# Altium schematic sheet 2 - 5V Buck.SchDoc
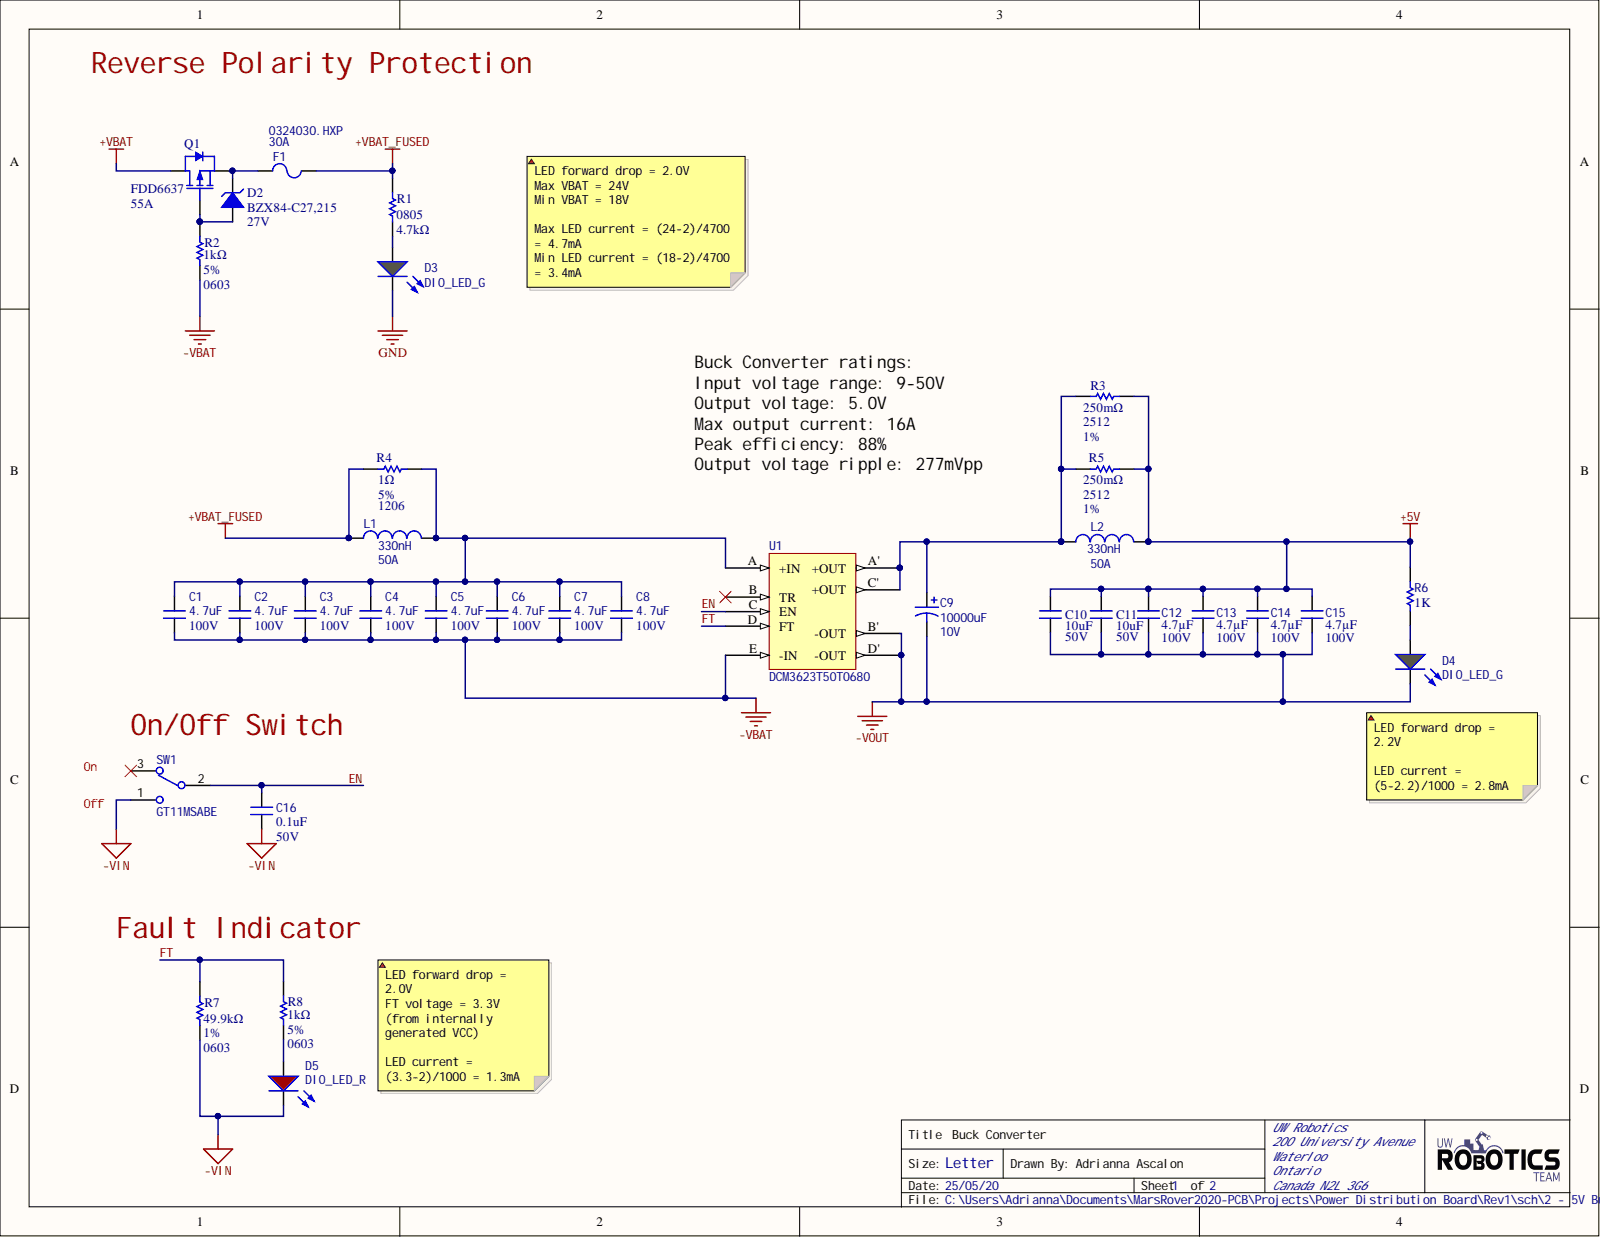
[diagram p0: 2 - 5V Buck.SchDoc]

## Parts
C1  4.7uF  Voltage: 100V  [CAPC5750X7R2A]
C2  4.7uF  Voltage: 100V  [CAPC5750X7R2A]
C3  4.7uF  Voltage: 100V  [CAPC5750X7R2A]
C4  4.7uF  Voltage: 100V  [CAPC5750X7R2A]
C5  4.7uF  Voltage: 100V  [CAPC5750X7R2A]
C6  4.7uF  Voltage: 100V  [CAPC5750X7R2A]
C7  4.7uF  Voltage: 100V  [CAPC5750X7R2A]
C8  4.7uF  Voltage: 100V  [CAPC5750X7R2A]
C9  Capacitance: 10000uF  Voltage Rating: 10V  [CAP_UBY_18X25_NC]  (hidden comment: URS1A103MHD1TN)
C10  10uF  Voltage Rating: 50V  [CAPC1206(3216)]
C11  10uF  Voltage Rating: 50V  [CAPC1206(3216)]
C12  Capacitance: 4.7µF  Voltage Rating: 100V  [CAPC3216X190X50LL20T25]  (hidden comment: 12061Z475KAT2A)
C13  Capacitance: 4.7µF  Voltage Rating: 100V  [CAPC3216X190X50LL20T25]  (hidden comment: 12061Z475KAT2A)
C14  Capacitance: 4.7µF  Voltage Rating: 100V  [CAPC3216X190X50LL20T25]  (hidden comment: 12061Z475KAT2A)
C15  Capacitance: 4.7µF  Voltage Rating: 100V  [CAPC3216X190X50LL20T25]  (hidden comment: 12061Z475KAT2A)
C16  value 0.1uF  Voltage Rating: 50V  [CAPC0603(1608)55_N]  (hidden comment: CAP_0.1uF_50V_0603)
D2  Manufacturer Part Number 1: BZX84-C27,215  Working Voltage: 27V  [SOT23-3]  (hidden comment: DIO_ZENER_27V)
D3  DIO_LED_G  [LED_0805_LINE]
D4  DIO_LED_G  [LED_0805_LINE]
D5  DIO_LED_R  [LED_0805_LINE]
F1  0324030.HXP  Current: 30A
L1  Inductance: 330nH  Max DC Current: 50A  [WE-HCM 1390]  (hidden comment: 744309033)
L2  Inductance: 330nH  Max DC Current: 50A  [WE-HCM 1390]  (hidden comment: 744309033)
Q1  FDD6637  Continuous Drain Current (ID): 55A
R1  value 4.7kΩ  Case Code (Imperial): 0805  [RESC2012X60N]  (hidden comment: RES_4.7kΩ_0805)
R2  value 1kΩ  Case/Package: 0603  Tolerance: 5%  [RESC0603(1608)_N]  (hidden comment: RES_1kΩ_0603)
R3  Package Reference: 2512  Resistance: 250mΩ  [RESC6432X89X76NL25T25]  (hidden comment: WSL2512R2500FEA)
R4  Resistance: 1Ω  Tolerance: 5%  [RESC1206(3216)_N]  (hidden comment: RES_1Ω_1206)
R5  Package Reference: 2512  Resistance: 250mΩ  Resistance Tolerance: 1%  [RESC6432X89X76NL25T25]  (hidden comment: WSL2512R2500FEA)
R6  value 1K  [RESC0603(1608)_N]  (hidden comment: RES_1K_0603)
R7  value 49.9kΩ  Case/Package: 0603  Resistance: 49.9kΩ  Tolerance: 1%  [RESC0603(1608)_N]  (hidden comment: RES_49.9kΩ_0603)
R8  value 1kΩ  Case/Package: 0603  Tolerance: 5%  [RESC0603(1608)_N]  (hidden comment: RES_1kΩ_0603)
SW1  GT11MSABE
U1  DCM3623T50T0680  [DCM3623T50T0680]

## Nets
+5V: C10.2 C11.2 C12.2 C13.2 C14.2 C15.2 L2.2 R3.2 R5.2 R6.2
+VBAT: Q1.2
+VBAT_FUSED: F1.2 L1.1 R1.2 R4.1
-VBAT: C1.1 C2.1 C3.1 C4.1 C5.1 C6.1 C7.1 C8.1 R2.1 U1.E(-IN)
-VIN: C16.1 D5.2 R7.2 SW1.1
-VOUT: C9.2 C10.1 C11.1 C12.1 C13.1 C14.1 C15.1 D4.2 U1.B'(-OUT) U1.D'(-OUT)
GND: D3.2
EN: C16.2 SW1.2 U1.C(EN)
FT: R7.1 R8.2 U1.D(FT)
NetC1_2: C1.2 C2.2 C3.2 C4.2 C5.2 C6.2 C7.2 C8.2 L1.2 R4.2 U1.A(+IN)
NetC9_1: C9.1 L2.1 R3.1 R5.1 U1.A'(+OUT) U1.C'(+OUT)
NetD2_1: D2.1 Q1.1 R2.2
NetD2_3: D2.3 F1.1 Q1.3
NetD3_1: D3.1 R1.1
NetD4_1: D4.1 R6.1
NetD5_1: D5.1 R8.1

Not connected: SW1 3; U1 B(TR)

## Library data of the parts
C1-C8 = CAP_4.7uF_100V_2220: CAP CER 4.7UF 100V X7R 2220; Manufacturer: TDK; Manufacturer Part Number: C5750X7R2A475M230KA; Tolerance: 20%; Capacitance: 4.7uF; Capacitor Value: 4.7uF; Case/Package: 2220; Case Code (Imperial): 2220; Case Code (Metric): 5750; Category: Ceramic Capacitors; Component Kind: Standard; ComponentLink1Description: https://datasheet.ciiva.com/24216/c5750x7r2a475m230ka-265798-24216581.pdf?src-supplier=Mouser; ComponentLink1URL: https://datasheet.ciiva.com/24216/c5750x7r2a475m230ka-265798-24216581.pdf?src-supplier=Mouser; ComponentLink2Description: https://datasheet.ciiva.com/16427/e413-c-16427893.pdf?src-supplier=Future+Electronics; ComponentLink2URL: https://datasheet.ciiva.com/16427/e413-c-16427893.pdf?src-supplier=Future+Electronics; ComponentLink3Description: https://datasheet.ciiva.com/28563/1484596-28563354.pdf?src-supplier=Element14; ComponentLink3URL: https://datasheet.ciiva.com/28563/1484596-28563354.pdf?src-supplier=Element14; ComponentLink4Description: https://datasheet.ciiva.com/22648/1642577-22648731.pdf?src-supplier=Element14; ComponentLink4URL: https://datasheet.ciiva.com/22648/1642577-22648731.pdf?src-supplier=Element14; Composition: Ceramic; Depth: 5mm; Dielectric: X7R; Height: 2.3mm; Lead Free: Lead Free; Length: 5.7mm; Max Operating Temperature: 125°C; Min Operating Temperature: -55°C; Mount: Surface Mount; Number of Pins: 2; Package Quantity: 1; Packaging: Tape and Reel; Pricing 1: 1=2.58000, 10=2.08700, 100=1.59390 (USD); REACH SVHC: No SVHC; RoHS: Yes; Stock 1: 45989; Supplier 1: Digi-Key; Supplier Part Number 1: 445-1450-1-ND; Termination: SMD/SMT; Thickness: 0.098inch; Voltage Rating: 100V; Voltage Rating (DC): 100V; Weight: 0.016932oz; Width: 0.197inch; Library Name: UWRT_Schematic_Library_2020.SchLib
C9 = URS1A103MHD1TN: CAP ALUM 10000UF 20% 10V RADIAL; Manufacturer 1: Nichicon; Life (Hours): 2000Hour; Ripple Current: 2.35A; Case/Package: Radial; Component Kind: Standard; ComponentLink1Description: https://datasheet.ciiva.com/29548/e-rs-29548199.pdf?src-supplier=Digi-Key; ComponentLink1URL: https://datasheet.ciiva.com/29548/e-rs-29548199.pdf?src-supplier=Digi-Key; ComponentLink2Description: https://datasheet.ciiva.com/29548/e-rs-29548200.pdf?src-supplier=Digi-Key; ComponentLink2URL: https://datasheet.ciiva.com/29548/e-rs-29548200.pdf?src-supplier=Digi-Key; Height - Seated (Max): 1.043inch; Lead Pitch: 0.295inch; Manufacturer Part Number 1: URS1A103MHD1TN; Max Operating Temperature: 85°C; Min Operating Temperature: -40°C; Mount: Through Hole; Package Quantity: 250; Packaging: Tape and Reel; Pricing 1: 1=2.34000, 10=1.89600, 100=1.44770 (USD); RoHS: Yes; Stock 1: 703; Supplier 1: Digi-Key; Supplier Part Number 1: 493-12364-1-ND; Tolerance: 20%; Library Name: UWRT_Schematic_Library_2020.SchLib
C10 C11 = CAP_10uF_50V_1206: CAP CER 10UF 50V X5R 1206; Manufacturer 1: Murata; Manufacturer Part Numer 1: GRT31CR61H106KE01L; Component Kind: Standard; Dimensions: 0.126" L x 0.063" W (3.20mm x 1.6mm); Mount: Surface Mount; Package: 1206 (3216 Metric); Signal Integrity: Cap; Simulation: CAP; Supplier 1: Digi-Key; Supplier Part Number 1: 490-12456-1-ND; Value: 10uF; Library Name: UWRT_Schematic_Library_2020.SchLib
C12-C15 = 12061Z475KAT2A: Chip Capacitor, 4.7 uF, +/- 10%, 100 V, -55 to 125 degC, 1206 (3216 Metric), RoHS, Tape and Reel; Manufacturer: AVX; Ceramic Capacitor Case: 1206[3216Metric]; Dielectric Characteristic: X7S; SVHC: NoSVHC(17-Dec-2015); Capacitance Tolerance: ±10%; Component Kind: Standard; Datasheet URL: https://datasheet.ciiva.com/22677/1789384-22677168.pdf; Datasheet Version: Oct-13; Manufacturer URL: http://www.avx.com/; MSL: MSL1-Unlimited; Operating Temperature Max: 125degC; Operating Temperature Min: -55degC; Packaging: CutTape; Library Name: UWRT_Schematic_Library_2020.SchLib
C16 = CAP_0.1uF_50V_0603: CAP CER 0.1UF 50V X7R 0603; Manufacturer 1: Yageo; Manufacturer Part Number 1: CC0603KRX7R9BB104; Component Kind: Standard; Dimensions: 0.063" L x 0.031" W (1.60mm x 0.80mm); Mount: Surface Mount; Package: 0603 (1608 Metric); Signal Integrity: Cap; Simulation: CAP; Supplier 1: Digi-Key; Supplier Part Number 1: 311-1344-1-ND; Temperature Coefficient: X7R; Library Name: UWRT_Schematic_Library_2020.SchLib
D2 = DIO_ZENER_27V: DIODE ZENER 27V 250MW SOT23; Manufacturer 1: Nexperia USA Inc.; Forward Voltage: 0.9V; Tolerance: 5%; Component Kind: Standard; Mount: Surface Mount; Package/Case: SOT-23-3; Supplier 1: Digi-Key; Supplier Part Number 1: 1727-5026-2-ND; Library Name: UWRT_Schematic_Library_2020.SchLib
D3 D4 = DIO_LED_G: LED GREEN DIFFUSED 0805 SMD; Manufacturer 1: OSRAM; Manufacturer Part Number 1: LG R971-KN-1; Forward Voltage Drop: 2.2V; Component Kind: Standard; Mount: Surface Mount; Package: 0805 (2012 Metric); Simulation: LED1; Supplier 1: Digi-Key; Supplier Part Number 1: 475-1410-1-ND; Library Name: UWRT_Schematic_Library_2020.SchLib
D5 = DIO_LED_R: LED RED DIFFUSED 0805 SMD; Manufacturer 1: OSRAM; Manufacturer Part Number 1: LS R976-NR-1; Forward Voltage Drop: 2V; Component Kind: Standard; Mount: Surface Mount; Package: 0805 (2012 Metric); Simulation: LED1; Supplier 1: Digi-Key; Supplier Part Number 1: 475-1278-1-ND; Library Name: UWRT_Schematic_Library_2020.SchLib
F1 = 0324030.HXP: FUSE, AXIAL, 30A, 6.3X32MM, FAST ACTING; Voltage Rating VAC:250V; Voltage Rating VDC:125V; Fuse Current:30A; Breaking Capacity:100A @ 250VAC / 1kA @ 75VDC / 400A @ 125VAC/VDC; Fuse Size Code:3AG; Fuse Size Metric:6.3mm x 32mm; Manufacturer 1: Littelfuse; Approvals: UL,CE,PSE,K-Mark; DC Cold Resistance: 1.82mR; Fuse Type: Cartridge, Ceramic; Voltage Rating (AC): 250V; Voltage Rating (DC): 125V; Case/Package: Axial; Component Kind: Standard; ComponentLink1Description: https://datasheet.ciiva.com/4485/littelfuse-fuse-314p-324p-185296-4485137.pdf?src-supplier=Mouser; ComponentLink1URL: https://datasheet.ciiva.com/4485/littelfuse-fuse-314p-324p-185296-4485137.pdf?src-supplier=Mouser; ComponentLink2Description: https://datasheet.ciiva.com/7462/littelfuse-fuse-314-324-7462439.pdf?src-supplier=Digi-Key; ComponentLink2URL: https://datasheet.ciiva.com/7462/littelfuse-fuse-314-324-7462439.pdf?src-supplier=Digi-Key; Current Rating: 30A; Diameter: 6.985mm; Fuse Current: 30A; Housing Material: Ceramic; Lead Free: Lead Free; Length: 32.72mm; Manufacturer Part Number 1: 0324030.HXP; Max Operating Temperature: 125°C; Min Operating Temperature: -55°C; Mount: Through Hole; Number of Pins: 2; Package Quantity: 100; Packaging: Bulk; Pricing 1: 1=2.28000, 5=2.17000, 10=2.06100, 50=1.66300, 100=1.40990, 250=1.37372, 500=1.15680, 1000=1.03028, 5000=0.90375 (USD); Radiation Hardening: No; REACH SVHC: No SVHC; Resistance: 1.82mR; RoHS: Yes; Stock 1: 124; Supplier 1: Digi-Key; Supplier Part Number 1: F4845-ND; Termination: Axial; Working Voltage: 250V; Library Name: UWRT_Schematic_Library_2020.SchLib
L1 L2 = 744309033: Wurth Shielded Wire-wound SMD Inductor with a MnZn Core, 0.33 µH ±20% Flat Wire Winding 47.5A Idc; Manufacturer 1: Wurth Electronics; Core Material: Zinc; DC Resistance (DCR): 0.165mR; Saturation Current: 62.5A; Self Resonant Frequency: 158MHz; Test Frequency: 100kHz; Case/Package: Nonstandard; Component Kind: Standard; ComponentLink1Description: https://datasheet.ciiva.com/6697/744309033-6697424.pdf?src-supplier=Digi-Key; ComponentLink1URL: https://datasheet.ciiva.com/6697/744309033-6697424.pdf?src-supplier=Digi-Key; ComponentLink2Description: https://datasheet.ciiva.com/7764/1645981-7764994.pdf?src-supplier=Element14; ComponentLink2URL: https://datasheet.ciiva.com/7764/1645981-7764994.pdf?src-supplier=Element14; ComponentLink3Description: https://datasheet.ciiva.com/13320/744309033-25565-13320700.pdf?src-supplier=Mouser; ComponentLink3URL: https://datasheet.ciiva.com/13320/744309033-25565-13320700.pdf?src-supplier=Mouser; Composition: Wirewound; Current Rating: 47.5A; Height: 9mm; Height - Seated (Max): 0.374inch; Inductance Tolerance: 20%; Lead Free: Lead Free; Length: 0.551inch; Manufacturer Part Number 1: 744309033; Max Operating Temperature: 125°C; Min Operating Temperature: -40°C; Mount: Surface Mount; Package Quantity: 300; Packaging: Tape and Reel; Pricing 1: 1=2.22000, 10=2.17000, 25=2.10000, 50=2.02000, 100=1.95000 (USD); REACH SVHC: Unknown; RMS Current (Irms): 50A; RoHS: Yes; Shielding: Shielded; Stock 1: 186; Supplier 1: Newark; Termination: SMD/SMT; Tolerance: 20%; Width: 0.512inch; Library Name: UWRT_Schematic_Library_2020.SchLib
Q1 = FDD6637: Trans MOSFET P-CH 35V 13A 3-Pin(2+Tab) DPAK T/R; Manufacturer 1: ON Semiconductor / Fairchild; Drain to Source Breakdown Voltage: -35V; Drain to Source Resistance: 11.6mR; Element Configuration: Single; Fall Time: 36ns; Gate to Source Voltage (Vgs): 25V; Input Capacitance: 2.37nF; Max Power Dissipation: 57W; Nominal Vgs: -1.6V; Number of Elements: 1; Power Dissipation: 3.1W; Rise Time: 10ns; Turn-Off Delay Time: 62ns; Turn-On Delay Time: 18ns; Case/Package: TO-252; Component Kind: Standard; ComponentLink1Description: https://datasheet.ciiva.com/34303/1958253-34303413.pdf?src-supplier=Jameco; ComponentLink1URL: https://datasheet.ciiva.com/34303/1958253-34303413.pdf?src-supplier=Jameco; ComponentLink2Description: https://datasheet.ciiva.com/15137/getdatasheetpartid-35276-15137060.pdf?src-supplier=Verical; ComponentLink2URL: https://datasheet.ciiva.com/15137/getdatasheetpartid-35276-15137060.pdf?src-supplier=Verical; ComponentLink3Description: https://datasheet.ciiva.com/4442/fdd6637-77901-4442502.pdf?src-supplier=Mouser; ComponentLink3URL: https://datasheet.ciiva.com/4442/fdd6637-77901-4442502.pdf?src-supplier=Mouser; ComponentLink4Description: https://datasheet.ciiva.com/25625/0900766b810c960f-25625341.pdf?src-supplier=RSComponents; ComponentLink4URL: https://datasheet.ciiva.com/25625/0900766b810c960f-25625341.pdf?src-supplier=RSComponents; ComponentLink5Description: https://datasheet.ciiva.com/26790/fdd6637-26790820.pdf?src-supplier=IIIC; ComponentLink5URL: https://datasheet.ciiva.com/26790/fdd6637-26790820.pdf?src-supplier=IIIC; ComponentLink6Description: https://datasheet.ciiva.com/6382/fdd6637-6382455.pdf?src-supplier=Digi-Key; ComponentLink6URL: https://datasheet.ciiva.com/6382/fdd6637-6382455.pdf?src-supplier=Digi-Key; ComponentLink7Description: https://datasheet.ciiva.com/28548/686553-28548172.pdf?src-supplier=Element14; ComponentLink7URL: https://datasheet.ciiva.com/28548/686553-28548172.pdf?src-supplier=Element14; ComponentLink8Description: https://datasheet.ciiva.com/6382/fdd6637-6382456.pdf?src-supplier=Digi-Key; ComponentLink8URL: https://datasheet.ciiva.com/6382/fdd6637-6382456.pdf?src-supplier=Digi-Key; ComponentLink9Description: https://datasheet.ciiva.com/6382/fdd6637-6382457.pdf?src-supplier=Digi-Key; ComponentLink9URL: https://datasheet.ciiva.com/6382/fdd6637-6382457.pdf?src-supplier=Digi-Key; Drain to Source Voltage (Vdss): 35V; Height: 2.39mm; Lead Free: Lead Free; Length: 6.73mm; Manufacturer Part Number 1: FDD6637; Max Operating Temperature: 150°C; Min Operating Temperature: -55°C; Mount: Surface Mount; Number of Pins: 3; On-State Resistance: 11.6MR; Package Quantity: 1; Packaging: Tape and Reel; Pricing 1: 1=1.02000, 10=0.90700, 25=0.86120, 100=0.64600, 250=0.63980, 500=0.54752, 1000=0.44602 (USD); Radiation Hardening: No; Rds On Max: 11.6mR; REACH SVHC: No SVHC; RoHS: Yes; Stock 1: 15110; Supplier 1: Digi-Key; Supplier Part Number 1: FDD6637CT-ND; Threshold Voltage: -1.6V; Weight: 0.26037g; Width: 6.22mm; Library Name: UWRT_Schematic_Library_2020.SchLib
R1 = RES_4.7kΩ_0805: RES SMD 4.7K OHM 5% 1/8W 0805; Manufacturer 1: Yageo; Manufacturer Part Number 1: RC0805JR-074K7L; Power Rating: 125mW; Resistance: 4.7kR; Temperature Coefficient: 100ppm/°C; Voltage Rating: 150V; Case/Package: Molded; Case Code (Metric): 2012; Component Kind: Standard; ComponentLink1Description: https://datasheet.ciiva.com/7941/442941-7941973.pdf?src-supplier=Element14; ComponentLink1URL: https://datasheet.ciiva.com/7941/442941-7941973.pdf?src-supplier=Element14; ComponentLink2Description: https://datasheet.ciiva.com/7941/442941-7941974.pdf?src-supplier=Element14; ComponentLink2URL: https://datasheet.ciiva.com/7941/442941-7941974.pdf?src-supplier=Element14; ComponentLink3Description: https://datasheet.ciiva.com/17486/rc0805-17486827.pdf?src-supplier=Future+Electronics; ComponentLink3URL: https://datasheet.ciiva.com/17486/rc0805-17486827.pdf?src-supplier=Future+Electronics; ComponentLink4Description: https://datasheet.ciiva.com/12667/rc0805-12667037.pdf?src-supplier=Mouser; ComponentLink4URL: https://datasheet.ciiva.com/12667/rc0805-12667037.pdf?src-supplier=Mouser; Composition: Thick Film; Contact Plating: Tin,Lead,Matte; Depth: 1.25mm; Lead Free: Lead Free; Length: 2mm; Max Operating Temperature: 155°C; Military Standard: Not; Min Operating Temperature: -55°C; Mount: Surface Mount; Packaging: Tape and Reel; Pricing 1: 1=0.10000, 10=0.03500, 100=0.01400, 1000=0.00631, 2500=0.00548 (USD); Radiation Hardening: No; REACH SVHC: Unknown; RoHS: Yes; Stock 1: 2755291; Supplier 1: Digi-Key; Supplier Part Number 1: 311-4.7KARCT-ND; Termination: SMD/SMT; Tolerance: 5%; Library Name: UWRT_Schematic_Library_2020.SchLib
R2 R8 = RES_1kΩ_0603: RES SMD 1K OHM 5% 1/10W 0603; Manufacturer 1: Yageo; Manufacturer Part Number 1: RC0603JR-071KL; Case Code (Metric): 1608; Component Kind: Standard; Dimensions: 0.063" L x 0.031" W (1.60mm x 0.80mm); Mount: Surface Mount; Package: 0603 (1608 Metric); Signal Integrity: Res; Simulation: RESISTOR; Supplier 1: Digi-Key; Supplier Part Number 1: 311-1.0KGRCT-ND; Library Name: UWRT_Schematic_Library_2020.SchLib
R3 R5 = RES_250mΩ_2512: Chip Resistor, 250 mOhm, +/- 1%, 1 W, -65 to 170 degC, 2512 (6432 Metric), RoHS, Tape and Reel; Manufacturer: Vishay; Automotive Qualification Standard: AEC-Q200; Power Rating: 1W; Product Range: WSLSeries; Resistor Case Style: 2512[6432Metric]; Resistor Element Type: MetalStrip; SVHC: NoSVHC(15-Jun-2015); Temperature Coefficient: ±75ppm/°C; Component Kind: Standard; Datasheet URL: http://www.vishay.com/docs/30100/wsl.pdf; Datasheet Version: 07/2016; Manufacturer URL: http://www.vishay.com/; Mounting Technology: SurfaceMount; MSL: MSL1-Unlimited; Package Description: 2-Pin Surface Mount Device, Body 6.35 x 3.18 mm; Packaging: CutTape; Library Name: UWRT_Schematic_Library_2020.SchLib
  R3: Resistance Tolerance: 1%
R4 = RES_1Ω_1206: RES 1 OHM 5% 1/4W 1206; Manufacturer: KOA Speer; Manufacturer Part Number: RK73B2BTTE1R0J; Power Rating: 250mW; Temperature Coefficient: 200ppm/°C; Voltage Rating: 200V; Case/Package: 1206; Case Code (Imperial): 1206; Case Code (Metric): 3216; Category: Chip SMD Resistors; Component Kind: Standard; ComponentLink1Description: https://datasheet.ciiva.com/5555/rk73b-5555368.pdf?src-supplier=Mouser; ComponentLink1URL: https://datasheet.ciiva.com/5555/rk73b-5555368.pdf?src-supplier=Mouser; Composition: Thick Film; Height: 0.6mm; Length: 3.2mm; Max Operating Temperature: 155°C; Min Operating Temperature: -55°C; Package Quantity: 4000; Packaging: Tape and Reel; Pricing 1: 1=0.10000, 10=0.04600, 100=0.01880, 1000=0.00845 (USD); RoHS: Yes; Stock 1: 3828; Supplier 1: Digi-Key; Supplier Part Number 1: 2019-RK73B2BTTE1R0JCT-ND; Termination: SMD/SMT; Width: 1.6mm; Library Name: UWRT_Schematic_Library_2020.SchLib
R6 = RES_1K_0603: RES SMD 1K OHM 5% 1/10W 0603; Manufacturer 1: Yageo; Manufacturer Part Number 1: RC0603JR-071KL; Component Kind: Standard; Dimensions: 0.063" L x 0.031" W (1.60mm x 0.80mm); Mount: Surface Mount; Package: 0603 (1608 Metric); Signal Integrity: Res; Simulation: RESISTOR; Supplier 1: Digi-Key; Supplier Part Number 1: 311-1.0KGRCT-ND; Tolerance: 5%; Library Name: UWRT_Schematic_Library_2020.SchLib
R7 = RES_49.9kΩ_0603: RES 49.9K OHM 1% 1/10W 0603; Manufacturer: KOA Speer; Manufacturer Part Number 1: RK73H1JTTD4992F; Power Rating: 100mW; Temperature Coefficient: 100ppm/°C; Voltage Rating: 50V; Case Code (Metric): 1608; Category: Chip SMD Resistors; Component Kind: Standard; ComponentLink1Description: https://datasheet.ciiva.com/5555/rk73h-5555450.pdf?src-supplier=Mouser; ComponentLink1URL: https://datasheet.ciiva.com/5555/rk73h-5555450.pdf?src-supplier=Mouser; ComponentLink2Description: https://datasheet.ciiva.com/7693/549017-7693854.pdf?src-supplier=Element14; ComponentLink2URL: https://datasheet.ciiva.com/7693/549017-7693854.pdf?src-supplier=Element14; Composition: Thick Film; Depth: 0.8mm; Dimensions: 0.063" L x 0.031" W (1.60mm x 0.80mm); Height: 0.45mm; Lead Free: Lead Free; Length: 1.6mm; Max Operating Temperature: 155°C; Military Standard: Not; Min Operating Temperature: -55°C; Mount: Surface Mount; Number of Pins: 2; Package: 0603 (1608 Metric); Package Quantity: 5000; Packaging: Tape and Reel; Pricing 1: 1=0.10000, 10=0.02200, 100=0.00910, 1000=0.00408, 2500=0.00354 (USD); RoHS: Yes; Stock 1: 339046; Supplier 1: Digi-Key; Supplier Part Number 1: 2019-RK73H1JTTD4992FCT-ND; Termination: SMD/SMT; Voltage Rating (DC): 50V; Width: 0.8mm; Library Name: UWRT_Schematic_Library_2020.SchLib
SW1 = GT11MSABE: SWITCH, TOGGLE, SPDT, 20V; Manufacturer 1: ITT C&K; Actuator Length: 3.81mm; Actuator Material: Nylon,Glass,Polyamide; Actuator Type: Standard Round; Electrical Life: 40000Cycles; Illumination Type: Non-Illuminated; Power Rating: 400mW; Switch Function: On-On; Component Kind: Standard; ComponentLink1Description: https://datasheet.ciiva.com/2904/gt-1oct13-2904374.pdf?src-supplier=Digi-Key; ComponentLink1URL: https://datasheet.ciiva.com/2904/gt-1oct13-2904374.pdf?src-supplier=Digi-Key; ComponentLink2Description: https://datasheet.ciiva.com/2904/gt-1oct13-2904375.pdf?src-supplier=Digi-Key; ComponentLink2URL: https://datasheet.ciiva.com/2904/gt-1oct13-2904375.pdf?src-supplier=Digi-Key; ComponentLink3Description: https://datasheet.ciiva.com/2904/gt-1oct13-2904376.pdf?src-supplier=Digi-Key; ComponentLink3URL: https://datasheet.ciiva.com/2904/gt-1oct13-2904376.pdf?src-supplier=Digi-Key; ComponentLink4Description: https://datasheet.ciiva.com/16166/gt-1oct13-16166152.pdf?src-supplier=Onlinecomponents.com; ComponentLink4URL: https://datasheet.ciiva.com/16166/gt-1oct13-16166152.pdf?src-supplier=Onlinecomponents.com; Contact Material: Copper Alloy; Contact Plating: Gold; Depth: 12.45mm; Housing Material: Nylon; Lead Free: Lead Free; Length: 7.01mm; Manufacturer Part Number 1: GT11MSABE; Max Operating Temperature: 85°C; Max Voltage Rating (AC): 20V; Max Voltage Rating (DC): 20V; Mechanical Life: 40000Cycles; Min Operating Temperature: -30°C; Mount: Surface Mount,Brackets; Orientation: Right Angle; Package Quantity: 1; Packaging: Tape and Reel; Pricing 1: 1=6.06000, 10=5.45900, 25=5.18640, 50=4.96780, 100=4.80410, 250=4.55840 (USD); RoHS: Yes; Stock 1: 3465; Supplier 1: Digi-Key; Supplier Part Number 1: CKN1783CT-ND; Termination: Gull Wing; Throw Configuration: SPDT; Voltage Rating (AC): 20V; Voltage Rating (DC): 20V; Library Name: UWRT_Schematic_Library_2020.SchLib
U1 = DCM3623T50T0680: Component Kind: Standard; Library Name: UWRT_Schematic_Library_2020.SchLib
